# Altium schematic sheet Main Board-brushless_pwr-v2.0.0.SchDoc("Main Board-brushless_pwr-v2.0.0")
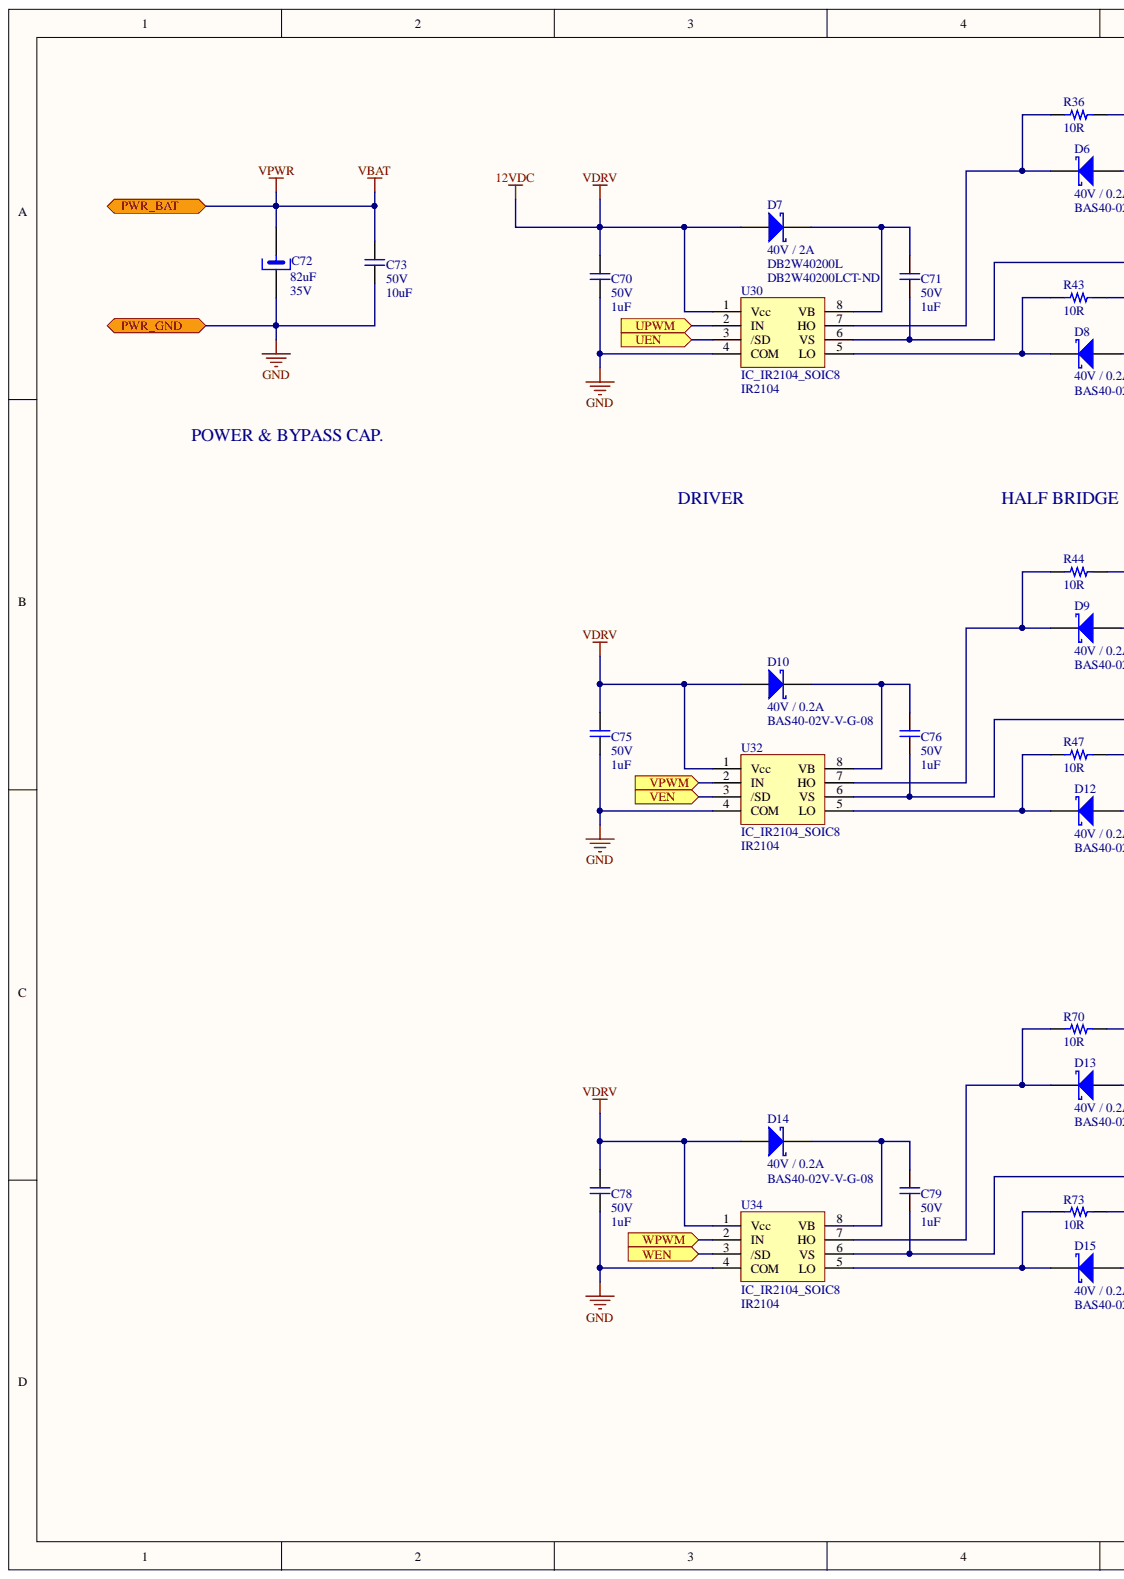
[diagram p0: Main Board-brushless_pwr-v2.0.0.SchDoc, left]
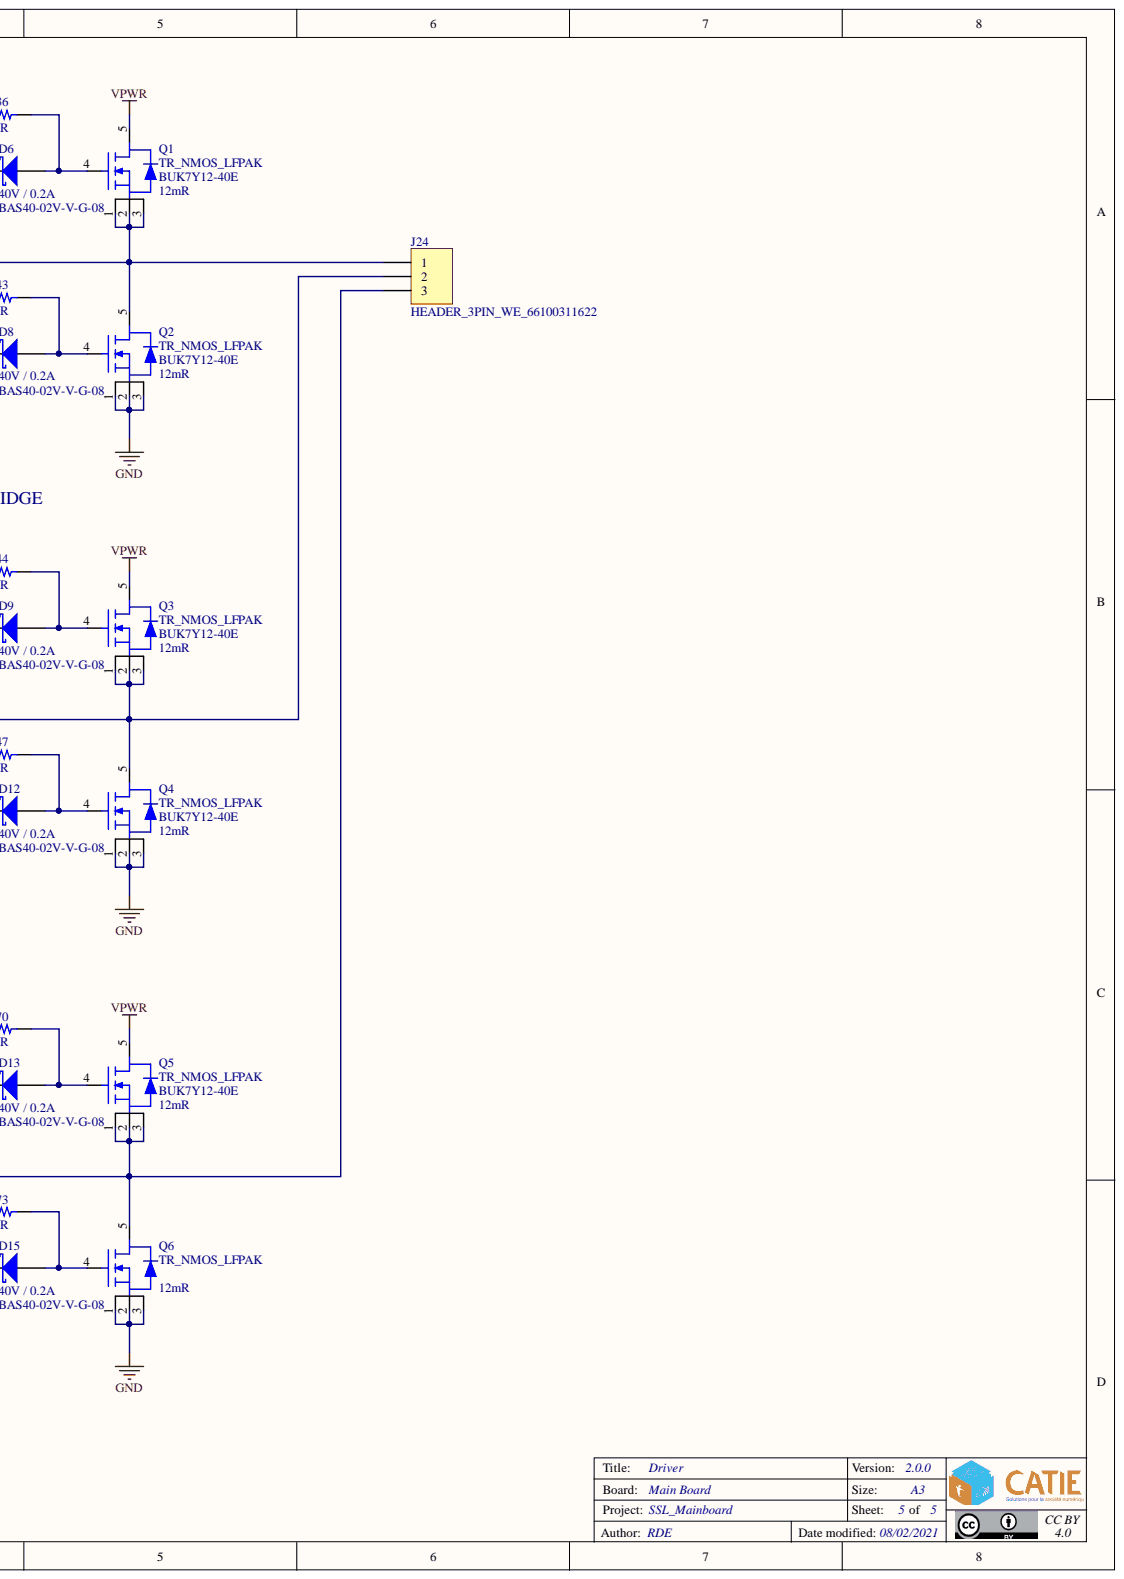
[diagram p1: Main Board-brushless_pwr-v2.0.0.SchDoc, right]

## Parts
C70  value 1uF  Rating: 50V  [CAP_CER_0805]  (hidden comment: CAP_CER_0805)
C71  value 1uF  Rating: 50V  [CAP_CER_0805]  (hidden comment: CAP_CER_0805)
C72  value 82uF  Rating: 35V  [WCAP-ATLL_6.3x15x2.5]  (hidden comment: ELEC CAPACITOR)
C73  value 10uF  Rating: 50V  [CAP_CER_1210]  (hidden comment: CAP_CER_1210)
C75  value 1uF  Rating: 50V  [CAP_CER_0805]  (hidden comment: CAP_CER_0805)
C76  value 1uF  Rating: 50V  [CAP_CER_0805]  (hidden comment: CAP_CER_0805)
C78  value 1uF  Rating: 50V  [CAP_CER_0805]  (hidden comment: CAP_CER_0805)
C79  value 1uF  Rating: 50V  [CAP_CER_0805]  (hidden comment: CAP_CER_0805)
D6  Rating: 40V / 0.2A  [DIODE_SOD523]  (hidden comment: Schottky Diode SOD523)
D7  Reference: DB2W40200L  Rating: 40V / 2A  [DIODE_SOD523]  (hidden comment: Schottky Diode SOD523)
D8  Rating: 40V / 0.2A  [DIODE_SOD523]  (hidden comment: Schottky Diode SOD523)
D9  Rating: 40V / 0.2A  [DIODE_SOD523]  (hidden comment: Schottky Diode SOD523)
D10  Reference: BAS40-02V-V-G-08  Rating: 40V / 0.2A  [DIODE_SOD523]  (hidden comment: Schottky Diode SOD523)
D12  Rating: 40V / 0.2A  [DIODE_SOD523]  (hidden comment: Schottky Diode SOD523)
D13  Rating: 40V / 0.2A  [DIODE_SOD523]  (hidden comment: Schottky Diode SOD523)
D14  Reference: BAS40-02V-V-G-08  Rating: 40V / 0.2A  [DIODE_SOD523]  (hidden comment: Schottky Diode SOD523)
D15  Rating: 40V / 0.2A  [DIODE_SOD523]  (hidden comment: Schottky Diode SOD523)
J24  HEADER_3PIN_WE_66100311622  [66100311622]
Q1  TR_NMOS_LFPAK  value 12mR  Reference: BUK7Y12-40E  [lfpak]
Q2  TR_NMOS_LFPAK  value 12mR  Reference: BUK7Y12-40E  [lfpak]
Q3  TR_NMOS_LFPAK  value 12mR  Reference: BUK7Y12-40E  [lfpak]
Q4  TR_NMOS_LFPAK  value 12mR  Reference: BUK7Y12-40E  [lfpak]
Q5  TR_NMOS_LFPAK  value 12mR  Reference: BUK7Y12-40E  [lfpak]
Q6  TR_NMOS_LFPAK  value 12mR  [lfpak]
R36  value 10R  [RES_0603]  (hidden comment: RES_0603)
R43  value 10R  [RES_0603]  (hidden comment: RES_0603)
R44  value 10R  [RES_0603]  (hidden comment: RES_0603)
R47  value 10R  [RES_0603]  (hidden comment: RES_0603)
R70  value 10R  [RES_0603]  (hidden comment: RES_0603)
R73  value 10R  [RES_0603]  (hidden comment: RES_0603)
U30  IC_IR2104_SOIC8  Reference: IR2104  [SOIC8]
U32  IC_IR2104_SOIC8  Reference: IR2104  [SOIC8]
U34  IC_IR2104_SOIC8  Reference: IR2104  [SOIC8]

## Ports
PWR_BAT, PWR_GND, UEN, UPWM, VEN, VPWM, WEN, WPWM

## Nets
12VDC: C70.2 C75.2 C78.2 D7.2 D10.2 D14.2 U30.1(Vcc) U32.1(Vcc) U34.1(Vcc)
GND: C70.1 C72.2 C73.1 C75.1 C78.1 Q2.1 Q2.2 Q2.3 Q4.1 Q4.2 Q4.3 Q6.1 Q6.2 Q6.3 U30.4(COM) U32.4(COM) U34.4(COM) ; port PWR_GND
UEN: U30.3(/SD) ; port UEN
UPWM: U30.2(IN) ; port UPWM
VBAT: C72.1 C73.2 Q1.5 Q3.5 Q5.5 ; port PWR_BAT
VEN: U32.3(/SD) ; port VEN
VPWM: U32.2(IN) ; port VPWM
WEN: U34.3(/SD) ; port WEN
WPWM: U34.2(IN) ; port WPWM
NetC71_1: C71.1 J24.1 Q1.1 Q1.2 Q1.3 Q2.5 U30.6(VS)
NetC71_2: C71.2 D7.1 U30.8(VB)
NetC76_1: C76.1 J24.2 Q3.1 Q3.2 Q3.3 Q4.5 U32.6(VS)
NetC76_2: C76.2 D10.1 U32.8(VB)
NetC79_1: C79.1 J24.3 Q5.1 Q5.2 Q5.3 Q6.5 U34.6(VS)
NetC79_2: C79.2 D14.1 U34.8(VB)
NetD6_1: D6.1 R36.1 U30.7(HO)
NetD6_2: D6.2 Q1.4 R36.2
NetD8_1: D8.1 R43.1 U30.5(LO)
NetD8_2: D8.2 Q2.4 R43.2
NetD9_1: D9.1 R44.1 U32.7(HO)
NetD9_2: D9.2 Q3.4 R44.2
NetD12_1: D12.1 R47.1 U32.5(LO)
NetD12_2: D12.2 Q4.4 R47.2
NetD13_1: D13.1 R70.1 U34.7(HO)
NetD13_2: D13.2 Q5.4 R70.2
NetD15_1: D15.1 R73.1 U34.5(LO)
NetD15_2: D15.2 Q6.4 R73.2

## Library data of the parts
C70 C71 C75 C76 C78 C79 = CAP_CER_0805: Capacitor ceramics SMD 0805; Manufacturer: Samsung; Tol: 10%; Reference: CL21B105KBFNNNG; Ceram: X7R; Type: Capacitor; Technology: CMS; Mount: Mnt; Library Name: Schematic_Components.SchLib; Component Kind: Standard; Case: 0805
C72 = CAP_ELEC_6.3x15mm: Manufacturer: Wuth Elektronik; Reference: 860160573010; Ceram: ALU; Type: Capacitor; Technology: TRAD; Mount: Mnt; Library Name: Schlib1.SchLib; Component Kind: Standard; Case: 6.3x15
C73 = CAP_CER_1210: Capacitor ceramics SMD 1210; Manufacturer: Samsung; Tol: 10%; Reference: CL32A106KBJNNNE; Ceram: X5R; Type: Capacitor; Technology: CMS; Mount: Mnt; Library Name: Schematic_Components.SchLib; Component Kind: Standard; Case: 1210
D6 D8 D9 D12 D13 D15 = DIODE_SCHOTTKY_SOD523: Sckottky diode SOD523 low reverse current; Manufacturer: Vishay; Value: 380mV; Reference: BAS40-02V-V-G-08; Type: Diode; Technology: CMS; Mount: Mnt; Library Name: Schematic_Components.SchLib; Component Kind: Standard; Case: SOD523
D7 = DIODE_SCHOTTKY_SOD123F: Sckottky diode SOD123F; Manufacturer: Panasonic; Value: 420mV; Type: Diode; Technology: CMS; Supplier Part Number 1: DB2W40200LCT-ND; Supplier 1: Digi-Key; Mount: Mnt; Library Name: Schematic_Components.SchLib; Component Kind: Standard; Case: SOD123F
D10 D14 = DIODE_SCHOTTKY_SOD523: Sckottky diode SOD523 low reverse current; Manufacturer: Vishay; Value: 380mV; Type: Diode; Technology: CMS; Mount: Mnt; Library Name: Schematic_Components.SchLib; Component Kind: Standard; Case: SOD523
J24 = HEADER_3PIN_WE_66100311622: WR-WTB 2.54 mm Intercom Male Horizontal Shrouded Header, 3p; Mount: DNP; Library Name: Schlib1.SchLib; Component Kind: Standard
Q1-Q5 = TR_NMOS_LFPAK: N MOSFET; Manufacturer: NEXPERIA; Rating: 40V; Type: N MOSFET; Technology: CMS; Mount: Mnt; Library Name: Schlib1.SchLib; Component Kind: Standard; Case: LFPAK56
Q6 = TR_NMOS_LFPAK: N MOSFET; Manufacturer: NEXPERIA; Reference: BUK7Y12-40E; Rating: 40V; Type: N MOSFET; Technology: CMS; Mount: Mnt; Library Name: Schlib1.SchLib; Component Kind: Standard; Case: LFPAK56
R36 R43 R44 R47 R70 R73 = RES_0603: Resistor SMD 0603; Manufacturer: Yageo; Tol: 1%; Reference: RC0603FR-0710RL; Rating: 0.1W; Type: Resistor; Technology: CMS; Mount: Mnt; Library Name: Schematic_Components.SchLib; Component Kind: Standard; Case: 0603
U30 U32 U34 = IC_IR2104_SOIC8: High-side low-side mosfet driver; Manufacturer: International Rectifier; Type: Integegrated Circuit; Technology: CMS; Mount: Mnt; Library Name: Schlib1.SchLib; Component Kind: Standard; Case: SOIC8
